# Altium schematic sheet modbus_gw.SchDoc(modbus_gw)
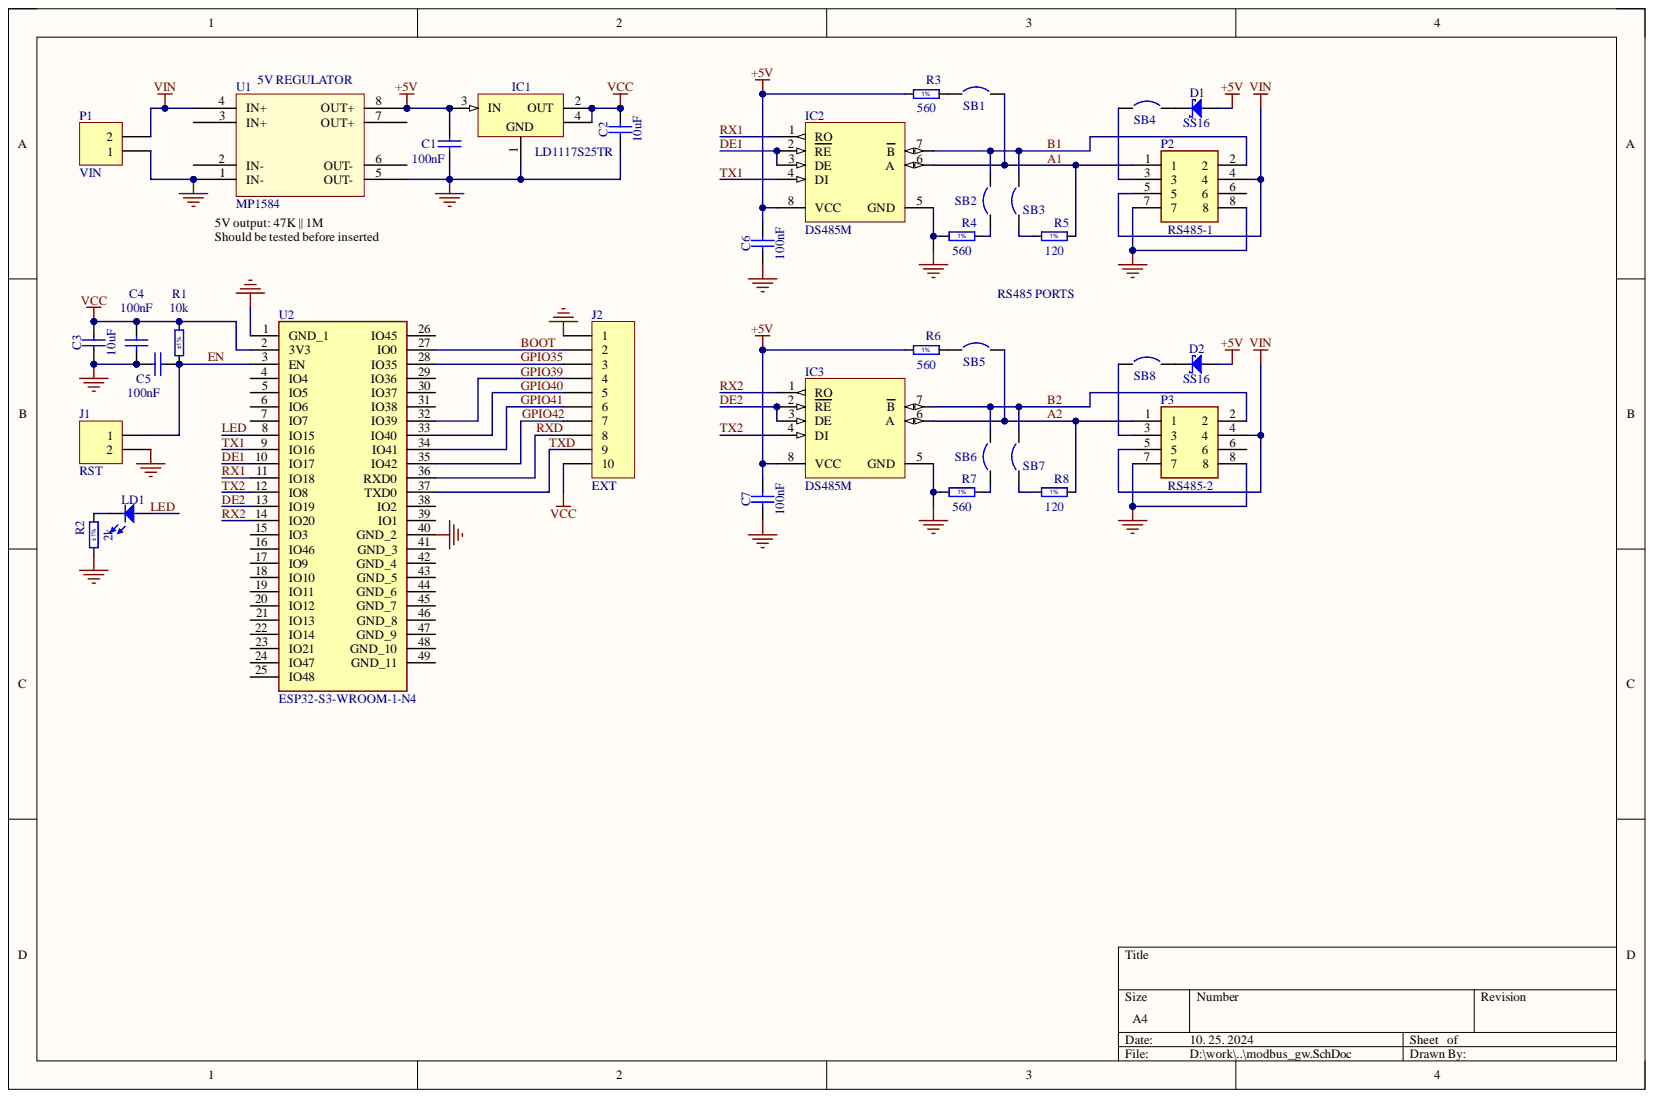
[diagram p0: modbus_gw.SchDoc]

## Parts
C1  100nF  [CAPC2012X135N]
C2  10uF  [CAPC2012X135N]
C3  10uF  [CAPC2012X135N]
C4  100nF  [CAPC2012X135N]
C5  100nF  [CAPC2012X135N]
C6  100nF  [CAPC2012X135N]
C7  100nF  [CAPC2012X135N]
D1  SS16  [DIOM5127X229N]
D2  SS16  [DIOM5127X229N]
IC1  LD1117S25TR  [SOT230P700X180-4N]
IC2  DS485M  [M08A_L]
IC3  DS485M  [M08A_L]
J1  RST  [HDR1X2]
J2  EXT  [HDR1X10]
LD1  Red  [LED_KINGBRIGHT_KPH-1608SURCK]  (value not drawn)
P1  VIN  [CONN-TH_2P-P5.08_KF142R-5.08-2P]
P2  RS485-1  [RJ45]
P3  RS485-2  [RJ45]
R1  10k  Tolerance: ±1%  [RESC2012X60N]
R2  2k  Tolerance: ±1%  [RESC2012X60N]
R3  560  [RESC3216X65N]
R4  560  [RESC3216X65N]
R5  120  [RESC3216X65N]
R6  560  [RESC3216X65N]
R7  560  [RESC3216X65N]
R8  120  [RESC3216X65N]
SB1  Circuit Breaker  [HDR1X2]  (value not drawn)
SB2  Circuit Breaker  [HDR1X2]  (value not drawn)
SB3  Circuit Breaker  [HDR1X2]  (value not drawn)
SB4  Circuit Breaker  [HDR1X2]  (value not drawn)
SB5  Circuit Breaker  [HDR1X2]  (value not drawn)
SB6  Circuit Breaker  [HDR1X2]  (value not drawn)
SB7  Circuit Breaker  [HDR1X2]  (value not drawn)
SB8  Circuit Breaker  [HDR1X2]  (value not drawn)
U1  MP1584  [MP1584EN]
U2  ESP32-S3-WROOM-1-N4  [ESP32S3WROOM1N4]

## Nets
+5V: C1.2 C6.2 C7.2 D1.2 D2.2 IC1.3(IN) IC2.8(VCC) IC3.8(VCC) R3.1 R6.1 U1.8(OUT+)
GND: C1.1 C2.1 C3.1 C4.1 C5.1 C6.1 C7.1 IC1.1 IC2.5(GND) IC3.5(GND) J1.2 J2.1 P1.1 P2.7 P2.8 P3.7 P3.8 R2.1 R4.1 R7.1 U1.1(IN-) U1.5(OUT-) U2.1(GND_1) U2.40(GND_2)
VCC: C2.2 C3.2 C4.2 IC1.2(OUT) IC1.4 J2.10 R1.2 U2.2(3V3)
VIN: P1.2 P2.4 P2.5 P3.4 P3.5 U1.4(IN+)
A1: IC2.6(A) P2.1 R5.2 SB1.2
A2: IC3.6(A) P3.1 R8.2 SB5.2
B1: IC2.7(B) P2.2 SB2.2 SB3.2
B2: IC3.7(B) P3.2 SB6.2 SB7.2
BOOT: J2.2 U2.27(IO0)
DE1: IC2.2(RE) IC2.3(DE) U2.10(IO17)
DE2: IC3.2(RE) IC3.3(DE) U2.13(IO19)
EN: C5.2 J1.1 R1.1 U2.3(EN)
GPIO35: J2.3 U2.28(IO35)
GPIO39: J2.4 U2.32(IO39)
GPIO40: J2.5 U2.33(IO40)
GPIO41: J2.6 U2.34(IO41)
GPIO42: J2.7 U2.35(IO42)
LED: LD1.2 U2.8(IO15)
RX1: IC2.1(RO) U2.11(IO18)
RX2: IC3.1(RO) U2.14(IO20)
RXD: J2.8 U2.36(RXD0)
TX1: IC2.4(DI) U2.9(IO16)
TX2: IC3.4(DI) U2.12(IO8)
TXD: J2.9 U2.37(TXD0)
NetD1_1: D1.1 SB4.2
NetD2_1: D2.1 SB8.2
NetLD1_1: LD1.1 R2.2
NetP2_3: P2.3 SB4.1
NetP3_3: P3.3 SB8.1
NetR3_2: R3.2 SB1.1
NetR4_2: R4.2 SB2.1
NetR5_1: R5.1 SB3.1
NetR6_2: R6.2 SB5.1
NetR7_2: R7.2 SB6.1
NetR8_1: R8.1 SB7.1

Not connected: P2 6; P3 6; U1 2(IN-) 3(IN+) 6(OUT-) 7(OUT+); U2 4(IO4) 5(IO5) 6(IO6) 7(IO7) 15(IO3) 16(IO46) 17(IO9) 18(IO10) 19(IO11) 20(IO12) 21(IO13) 22(IO14) 23(IO21) 24(IO47) 25(IO48) 26(IO45) 29(IO36) 30(IO37) 31(IO38) 38(IO2) 39(IO1) 41(GND_3) 42(GND_4) 43(GND_5) 44(GND_6) 45(GND_7) 46(GND_8) 47(GND_9) 48(GND_10) 49(GND_11)

## Library data of the parts
C1 C4 C5 = CC0805_100NF_50V_10%_X7R: Non-polarised capacitor; Manufacturer: GENERIC; Manufacturer1 Part Number: C2012X7R1H104K085; Part Description: SMD Multilayer Chip Ceramic Capacitor; Database Table Name: Capacitors SMD; TC: X7R; Tolerance: ±10%; Voltage: 50V; Case: 0805; Component Kind: Standard; Component Type: Standard; CreateDate: 2007. 12. 03.; HelpURL: \\cern.ch\dfs\Applications\Altium\Datasheets\CC0805_X7R_TDK_C.pdf; LatestRevisionDate: 2015. 04. 22.; Library Name: Capacitors.DbLib; Manufacturer1 ComponentHeight: 1mm; Manufacturer1 Example: TDK; Manufacturer Part Number: CC0805_100NF_50V_10%_X7R; Mounted: Yes; Part Number: CC0805_100NF_50V_10%_X7R; Pin Count: 2; Sense: No; SMD: Yes; Socket: No; Status: Preferred; Value: 100nF; Author: CERN DEM JLC
C2 C3 = CC0805_10UF_10V_10%_X7R: Non-polarised capacitor; Manufacturer: GENERIC; Manufacturer1 Part Number: GRM21BR71A106KE51L; Part Description: SMD Multilayer Chip Ceramic Capacitor; Database Table Name: Capacitors SMD; TC: X7R; Tolerance: ±10%; Voltage: 10V; Case: 0805; Component Kind: Standard; Component Type: Standard; CreateDate: 2012. 04. 30.; HelpURL: \\cern.ch\dfs\Applications\Altium\Datasheets\CC0805_X7R_MURATA.pdf; LatestRevisionDate: 2012. 04. 30.; Library Name: Capacitors.DbLib; Manufacturer1 ComponentHeight: 1.35mm; Manufacturer1 Example: MURATA; Manufacturer Part Number: CC0805_10UF_10V_10%_X7R; Mounted: Yes; Part Number: CC0805_10UF_10V_10%_X7R; Pin Count: 2; Sense: No; SMD: Yes; Socket: No; Status: Preferred; Value: 10uF; Author: CERN DEM JLC
C6 C7 = CC0805_100NF_50V_10%_X7R: Non-polarised capacitor; Manufacturer: GENERIC; Manufacturer1 Part Number: C2012X7R1H104K085AA; Part Description: SMD Multilayer Chip Ceramic Capacitor; Database Table Name: Capacitors SMD; TC: X7R; Tolerance: ±10%; Voltage: 50V; Case: 0805; Component Kind: Standard; Component Type: Standard; CreateDate: 2007. 12. 03.; HelpURL: \\cern.ch\dfs\Applications\Altium\Datasheets\CC0805_X7R_TDK_C.pdf; LatestRevisionDate: 2015. 04. 22.; Library Name: Capacitors.DbLib; Manufacturer1 ComponentHeight: 1mm; Manufacturer1 Example: TDK; Manufacturer Part Number: CC0805_100NF_50V_10%_X7R; Mounted: Yes; Part Number: CC0805_100NF_50V_10%_X7R; Pin Count: 2; Sense: No; SMD: Yes; Socket: No; Status: Preferred; Value: 100nF; Author: CERN DEM JLC
D1 D2 = SS16: Schottky Diode; Manufacturer: VISHAY GENERAL SEMICONDUCTOR; Part Description: Surface Mount Schottky Barrier Rectifier; Part Number: SS14; Bonding: No; Database Table Name: Diodes; Power: 1A; PressFit: No; Voltage: 60V; Case: DO-214AC; Component Kind: Standard; Component Type: Standard; ComponentHeight: 2.29mm; CreateDate: 2008. 07. 15.; Device: SS16; HelpURL: \\cern.ch\dfs\Applications\Altium\Datasheets\SS16.pdf; LatestRevisionDate: 2010. 08. 23.; Library Name: ICs And Semiconductors.DbLib; Manufacturer Part Number: SS16; Mounted: Yes; PackageDescription: DO-214-AC Molded Diode, Body 5.1x2.7mm, IPC Medium Density; Pin Count: 2; Sense: No; SMD: Yes; Socket: No; Author: CERN DEM JLC
IC1 = LD1117S25TR: 3-Terminal Regulator 1=GND 2=OUT 3=IN 4=OUT; Manufacturer: ST MICROELECTRONICS; Part Description: 2.5V, 800mA Low-Dropout Positive Voltage Regulator; Part Number: LD1117S33TR; Bonding: No; Database Table Name: Regulators; PressFit: No; Case: SOT223; Component Kind: Standard; Component Type: Standard; ComponentHeight: 1.8mm; CreateDate: 2014. 07. 09.; Device: LD1117S25TR; HelpURL: \\cern.ch\dfs\Applications\Altium\Datasheets\LD1117S25TR.pdf; LatestRevisionDate: 2014. 07. 09.; Library Name: ICs And Semiconductors.DbLib; Manufacturer Part Number: LD1117S25TR; Mounted: Yes; PackageDescription: SOT223, 2.30mm Pitch; 4 Pin, 3.70mm W X 6.70mm L X 1.80mm H body, IPC Medium Density; Pin Count: 4; Sense: No; SMD: Yes; Socket: No; Author: CERN DEM JLC
IC2 IC3 = DS485M: Low-Power RS-485/RS-422 Multipoint Transceiver, 8-pin Narrow SOIC; Manufacturer: National Semiconductor; CompType: Interface IC; PackageTopMarking: NSZXTT*DS485*M; PowerWise: N; Code_IPC: SOIC127P600-8; Code_JEDEC: MS-012-AA; Component Kind: Standard; ComponentLink1Description: DS485 Datasheet; ComponentLink1URL: http://www.national.com/ds/DS/DS485.pdf; ComponentLink2Description: DS485 Web Page; ComponentLink2URL: http://www.national.com/pf/DS/DS485.html; ComponentLink3Description: M08A Package Page; ComponentLink3URL: http://www.national.com/packaging/folders/m08a.html; DatasheetVersion: Jul-1998; Library Name: NSC Interface.IntLib; PackageDescription: SOIC Narrow, 4.9 x 3.9 x 1.45mm, 8 Lead, 1.27mm Pitch; PackageReference: M08A; PackageVersion: revM, May-2009; PartNumber: DS485M; PinCount: 8; Published: 4-Dec-2008; RoHS: N; Supplier 1: Farnell; Supplier Part Number 1: 9490078; Publisher: Altium Limited
J1 P1 = Header 2: Header, 2-Pin; Component Kind: Standard; LatestRevisionDate: 17-Jul-2002; LatestRevisionNote: Re-released for DXP Platform.; Library Name: Miscellaneous Connectors.IntLib; Publisher: Altium Limited
J2 = Header 10: Header, 10-Pin; Component Kind: Standard; LatestRevisionDate: 17-Jul-2002; LatestRevisionNote: Re-released for DXP Platform.; Library Name: Miscellaneous Connectors.IntLib; Publisher: Altium Limited
LD1 = LED_KINGBRIGHT_KPH-1608SURCK: LED Red 1C 2A; Part Description: SMD Hyper Red LED, Case 1.6mmX0.8mm(0603), 0.65mm Thickness; Bonding: No; Database Table Name: LEDs & Displays; PressFit: No; Case: 0603; Color: Red; Component Kind: Standard; Component Type: Standard; ComponentHeight: 0.65mm; CreateDate: 2017. 07. 03.; HelpURL: \\cern.ch\dfs\Applications\Altium\Datasheets\LED_KINGBRIGHT_KPH-1608SURCK.pdf; LatestRevisionDate: 2017. 07. 03.; Library Name: ICs And Semiconductors.DbLib; Manufacturer: KINGBRIGHT; Manufacturer Part Number: KPH-1608SURCK; Mounted: Yes; PackageDescription: SMT Hyper Red LED, Case 0603, Body L 1.6 x W 0.8mm H 0.65mm; Part Number: LED_KINGBRIGHT_KPH-1608SURCK; Pin Count: 2; Sense: No; SMD: Yes; Socket: No; Author: CERN DEM JLC
P2 P3 = 0428788410: Connector; Manufacturer_Name: Molex; Component Kind: Standard; Datasheet Link: https://media.digikey.com/pdf/Data%20Sheets/Molex%20PDFs/42878-yyyy_Dwg.pdf; Height: 12.95mm; Manufacturer_Part_Number: 0428788410
R1 R2 = R0805_10K_1%_0.125W_100PPM: Resistor - 1%; Manufacturer: GENERIC; Manufacturer1 Part Number: NRC10F1002TRF; Part Description: General Purpose Thick Film Chip Resistor; Database Table Name: Resistors SMD; Power: 0.125W; PressFit: No; TC: ±100ppm/°C; Case: 0805; Component Kind: Standard; Component Type: Standard; CreateDate: 2007. 12. 03.; HelpURL: \\cern.ch\dfs\Applications\Altium\Datasheets\R0805_NIC_NRC.pdf; LatestRevisionDate: 2008. 03. 13.; Library Name: Resistors.DbLib; Manufacturer1 ComponentHeight: 0.6mm; Manufacturer1 Example: NIC COMPONENT; Manufacturer Part Number: R0805_10K_1%_0.125W_100PPM; Mounted: Yes; Part Number: R0805_10K_1%_0.125W_100PPM; Pin Count: 2; Sense: No; SMD: Yes; Socket: No; Status: Preferred; Author: CERN DEM JLC
  R1: Value: 10k
  R2: Value: 2k
R3-R8 = R1206_4K7_1%_0.25W_100PPM: Resistor - 1%; Manufacturer: GENERIC; Manufacturer1 Part Number: NRC12F4701TRF; Part Description: General Purpose Thick Film Chip Resistor; Database Table Name: Resistors SMD; Power: 0.25W; PressFit: No; TC: ±100ppm/°C; Case: 1206; Component Kind: Standard; Component Type: Standard; CreateDate: 2007. 12. 03.; HelpURL: \\cern.ch\dfs\Applications\Altium\Datasheets\R1206_NIC_NRC.pdf; LatestRevisionDate: 2008. 03. 13.; Library Name: Resistors.DbLib; Manufacturer1 ComponentHeight: 0.65mm; Manufacturer1 Example: NIC COMPONENT; Manufacturer Part Number: R1206_4K7_1%_0.25W_100PPM; Mounted: Yes; Part Number: R1206_4K7_1%_0.25W_100PPM; Pin Count: 2; Sense: No; SMD: Yes; Socket: No; Status: Preferred; Tolerance: ±1%; Author: CERN DEM JLC
  R3 R4 R6 R7: Value: 560
  R5 R8: Value: 120
SB1-SB8 = Circuit Breaker: Solder: NO; Component Kind: Standard; Description: Circuit Breaker; Library Name: MCD_TOOLS.SCHLIB; Published: 24-Mar-1999; Revision: July-2002: Re-released for DXP Platform.; Publisher: Altium Limited
U2 = ESP32-S3-WROOM-1-N4: Integrated Circuit; Manufacturer_Name: Espressif Systems; Component Kind: Standard; Datasheet Link: https://www.espressif.com/sites/default/files/documentation/esp32-s3-wroom-1_wroom-1u_datasheet_en.pdf; Height: 3.25mm; Manufacturer_Part_Number: ESP32-S3-WROOM-1-N4; Mouser Part Number: 356-ESP32-S3WROOM1N4; Mouser Price/Stock: https://www.mouser.co.uk/ProductDetail/Espressif-Systems/ESP32-S3-WROOM-1-N4?qs=Li%252BoUPsLEnsO%2FkiJOUK0FQ%3D%3D
U1 = MP1584: Component Kind: Standard; Library Name: parts.SchLib
